# Altium schematic sheet megabit-I2C-TOP.SchDoc
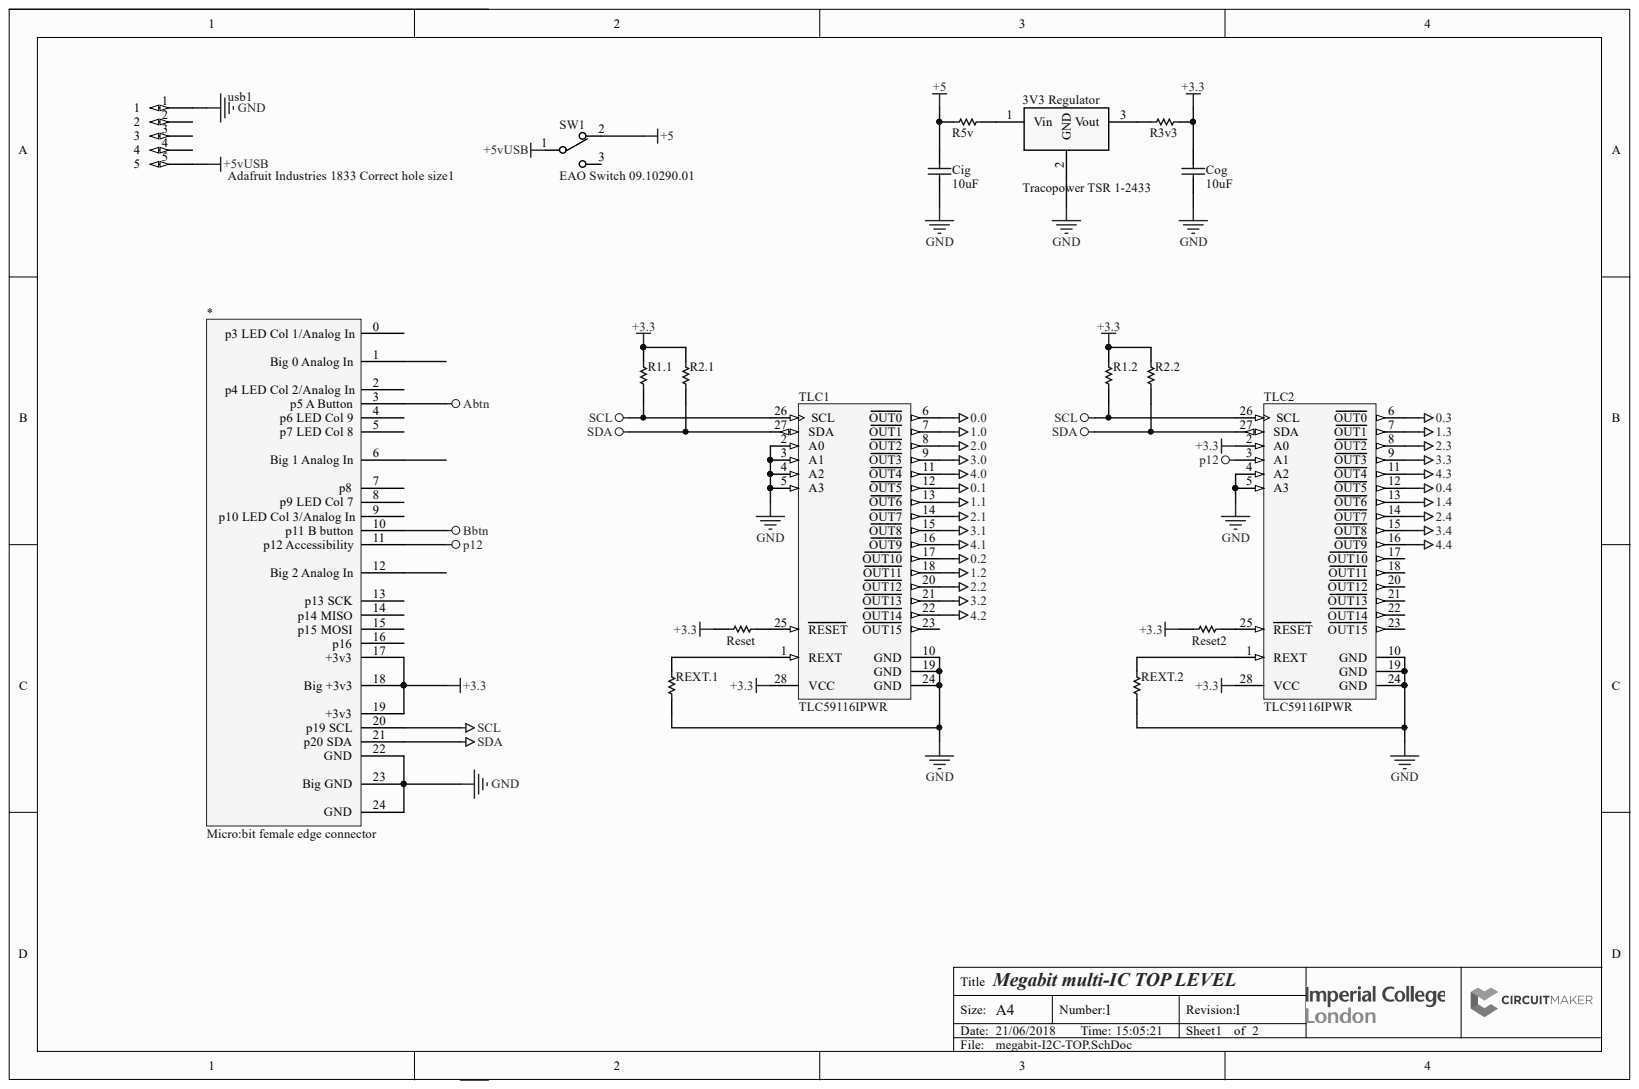
[diagram p0: megabit-I2C-TOP.SchDoc]

## Parts
3V3_Regulator  Tracopower TSR 1-2433  Manufacturer Part Number: TSR 1-2433  [PCB-vczw4772xz5kvcrv4x78-1]
*  Micro:bit female edge connector  [PCBComponent_1 - duplicate]
Cig  10uF  [Vishay K104K15X7RF5TL2]
Cog  10uF  [Vishay K104K15X7RF5TL2]
R1.1  Res1  [PCB-3613466-1]  (value not drawn)
R1.2  Res1  [PCB-3613466-1]  (value not drawn)
R2.1  Res1  [PCB-3613466-1]  (value not drawn)
R2.2  Res1  [PCB-3613466-1]  (value not drawn)
R3v3  Res1  [PCB-3613466-1]  (value not drawn)
R5v  Res1  [PCB-3613466-1]  (value not drawn)
Reset  Res1  [PCB-3613466-1]  (value not drawn)
Reset2  Res1  [PCB-3613466-1]  (value not drawn)
REXT.1  R-EXT  [PCB-3613466-1]
REXT.2  R-EXT  [PCB-3613466-1]
SW1  EAO Switch 09.10290.01  [PCB-1akl86m7w5ikau1ha4dm-1]
TLC1  TLC59116IPWR  [TI-PW28_L]
TLC2  TLC59116IPWR  [TI-PW28_L]
usb1  Adafruit Industries 1833 Correct hole size1  [PCB-lrx1ysvqy1j2hi3n37c6-1]

## Nets
0.0: TLC1.6(OUT0)
0.1: TLC1.12(OUT5)
0.2: TLC1.17(OUT10)
0.3: TLC2.6(OUT0)
0.4: TLC2.12(OUT5)
1.0: TLC1.7(OUT1)
1.1: TLC1.13(OUT6)
1.2: TLC1.18(OUT11)
1.3: TLC2.7(OUT1)
1.4: TLC2.13(OUT6)
2.0: TLC1.8(OUT2)
2.1: TLC1.14(OUT7)
2.2: TLC1.20(OUT12)
2.3: TLC2.8(OUT2)
2.4: TLC2.14(OUT7)
3.0: TLC1.9(OUT3)
3.1: TLC1.15(OUT8)
3.2: TLC1.21(OUT13)
3.3: TLC2.9(OUT3)
3.4: TLC2.15(OUT8)
4.0: TLC1.11(OUT4)
4.1: TLC1.16(OUT9)
4.2: TLC1.22(OUT14)
4.3: TLC2.11(OUT4)
4.4: TLC2.16(OUT9)
+3.3: *.17(+3v3) *.18(Big_+3v3) *.19(+3v3) Cog.2 R1.1.2 R1.2.2 R2.1.2 R2.2.2 R3v3.2 Reset.1 Reset2.1 TLC1.28(VCC) TLC2.2(A0) TLC2.28(VCC)
+5: Cig.2 R5v.1 SW1.2
+5VUSB: SW1.1 usb1.5
ABTN: *.3(p5_A_Button)
BBTN: *.10(p11_B_button)
GND: 3V3_Regulator.2 *.22(GND) *.23(Big_GND) *.24(GND) Cig.1 Cog.1 REXT.1.1 REXT.2.1 TLC1.2(A0) TLC1.3(A1) TLC1.4(A2) TLC1.5(A3) TLC1.10(GND) TLC1.19(GND) TLC1.24(GND) TLC2.4(A2) TLC2.5(A3) TLC2.10(GND) TLC2.19(GND) TLC2.24(GND) usb1.1
P12: *.11(p12_Accessibility) TLC2.3(A1)
SCL: *.20(p19_SCL) R1.1.1 R1.2.1 TLC1.26(SCL) TLC2.26(SCL)
SDA: *.21(p20_SDA) R2.1.1 R2.2.1 TLC1.27(SDA) TLC2.27(SDA)
Net3V3 Regulator_1: 3V3_Regulator.1 R5v.2
Net3V3 Regulator_3: 3V3_Regulator.3 R3v3.1
NetReset2_2: Reset2.2 TLC2.25(RESET)
NetReset_2: Reset.2 TLC1.25(RESET)
NetREXT.1_2: REXT.1.2 TLC1.1(REXT)
NetREXT.2_2: REXT.2.2 TLC2.1(REXT)
N00032:
N00033:

Not connected: * 0(p3_LED_Col_1/Analog_In) 1(Big_0_Analog_In) 2(p4_LED_Col_2/Analog_In) 4(p6_LED_Col_9) 5(p7_LED_Col_8) 6(Big_1_Analog_In) 7(p8) 8(p9_LED_Col_7) 9(p10_LED_Col_3/Analog_In) 12(Big_2_Analog_In) 13(p13_SCK) 14(p14_MISO) 15(p15_MOSI) 16(p16); SW1 3; TLC1 23(OUT15); TLC2 17(OUT10) 18(OUT11) 20(OUT12) 21(OUT13) 22(OUT14) 23(OUT15); usb1 2 3 4

## Library data of the parts
3V3_Regulator = CMP-395cf0c79334e69b-1: 1 A Watt, 4.75-36VDCVin, Quad Output, 3.3 V@1 A Switching Regulators DC-DC Converter; Input Voltage (DC): 4.75 V to 36.0 V; Number of Outputs: 1; Output Current: 1.00 A; Output Voltage: 3.30 V; Component Kind: Standard; Library Name: CommunityVault; Mounting Style: PCB; OctopartID: 395cf0c79334e69b; Octopart URL: http://octopart.com/tsr+1-2433-tracopower-9539342; REACH SVHC Compliance: No SVHC; RoHS: Compliant; Size-Height: 10.2 mm; Size-Width: 7.60 mm
* = CMP-mom7rix79jkpy4z4w6go-14: http://www.dgyuliang.net/html/279.html; Component Kind: Standard; CustomComponentID: mom7rix79jkpy4z4w6go; Library Name: CommunityVault
Cig Cog = CMP-124240-16: K Series 100nF 50 V ±10 % Tolerance X7R Radial Multilayer Ceramic Capacitor; Manufacturer Part Number: K104K15X7RF5TL2; Capacitance Tolerance: ±10%; Lead-Free Status: Lead Free; Lead Length: 30mm; Voltage Rating (DC): 50 V; Capacitance: 100 nF; Component Kind: Standard; Dielectric Characteristic: X7R; Dielectric Material: Ceramic; Library Name: CommunityVault; Mounting Style: Through Hole; Number of Pins: 2; OctopartID: e7292e20c87538ee; Octopart URL: http://octopart.com/k104k15x7rf5tl2-vishay+bccomponents-199226; Packaging: Cut Tape (CT), Tape & Reel (TR); RoHS: Compliant; Size-Height: 5.6mm; Size-Lead Diameter: 0.5mm; Size-Thickness: 2.6mm; Size-Width: 4.0mm
R1.1 R1.2 R2.1 R2.2 R3v3 R5v Reset Reset2 REXT.1 REXT.2 = CMP-b15c796618a36e9b-3: Resistor 0.25W; Component Kind: Standard; Library Name: CommunityVault; OctopartID: b15c796618a36e9b
SW1 = CMP-a30d6b12014b7cca-5: SLIDE SWITCH, SPDT, HORIZ; Series: 1K2; Switch Function Type: SPDT, R/A; Contact Configuration: SPDT; Voltage, Contac...; Contact Resistance: 22.0 mΩ; Insulation Resistance: 10.0 GΩ; Component Kind: Standard; Contacts Type: SPDT; Library Name: CommunityVault; Manufacturer Part Number: 09.10290.01; Mounting Style: PCB; OctopartID: a30d6b12014b7cca; Octopart URL: http://octopart.com/09.10290.01-eao+switch-18188064; Orientation: Right Angle; RoHS: Compliant
TLC1 TLC2 = CMP-1160291-2: 16-Bit Fast-Mode Plus (FM+) I2C Bus Constant-Current LED Sink Driver, 3 to 5.5 V, -40 to 85 degC, 28-pin SOP (PW28), Green (RoHS & no Sb/Br); Lead-Free Status: Lead Free; Number of Outputs: 16; Output Current: 0.12 A; Output Voltage: 17.0 V; Component Kind: Standard; Library Name: CommunityVault; Lifecycle Status: Active; Mounting Style: Surface Mount; Number of Pins: 28; OctopartID: 667eaf0d8e01b9b9; Octopart Url: https://octopart.com/tlc59116ipwr-texas+instruments-7982361; Packaging: Cut Tape (CT); REACH SVHC Compliance: No SVHC; RoHS: Compliant
usb1 = CMP-bfb17591a6f36076-4: Standoff; Threaded Female 1/4 Hex; 6-32 Thread; Length .875; Brass/Zinc; Manufacturer Part Number: 1833; Lead-Free Status: Lead Free; Component Kind: Standard; Gender: Female; Library Name: FavoritesLibrary; Material: Brass; OctopartID: bfb17591a6f36076; Octopart URL: http://octopart.com/1833-adafruit+industries-53099556; RoHS: Compliant; Size-Diameter: 6.35 mm; Size-Length: 22.2 mm; Size-Width: 6.35 mm
